# Altium schematic sheet Sheet1.SchDoc
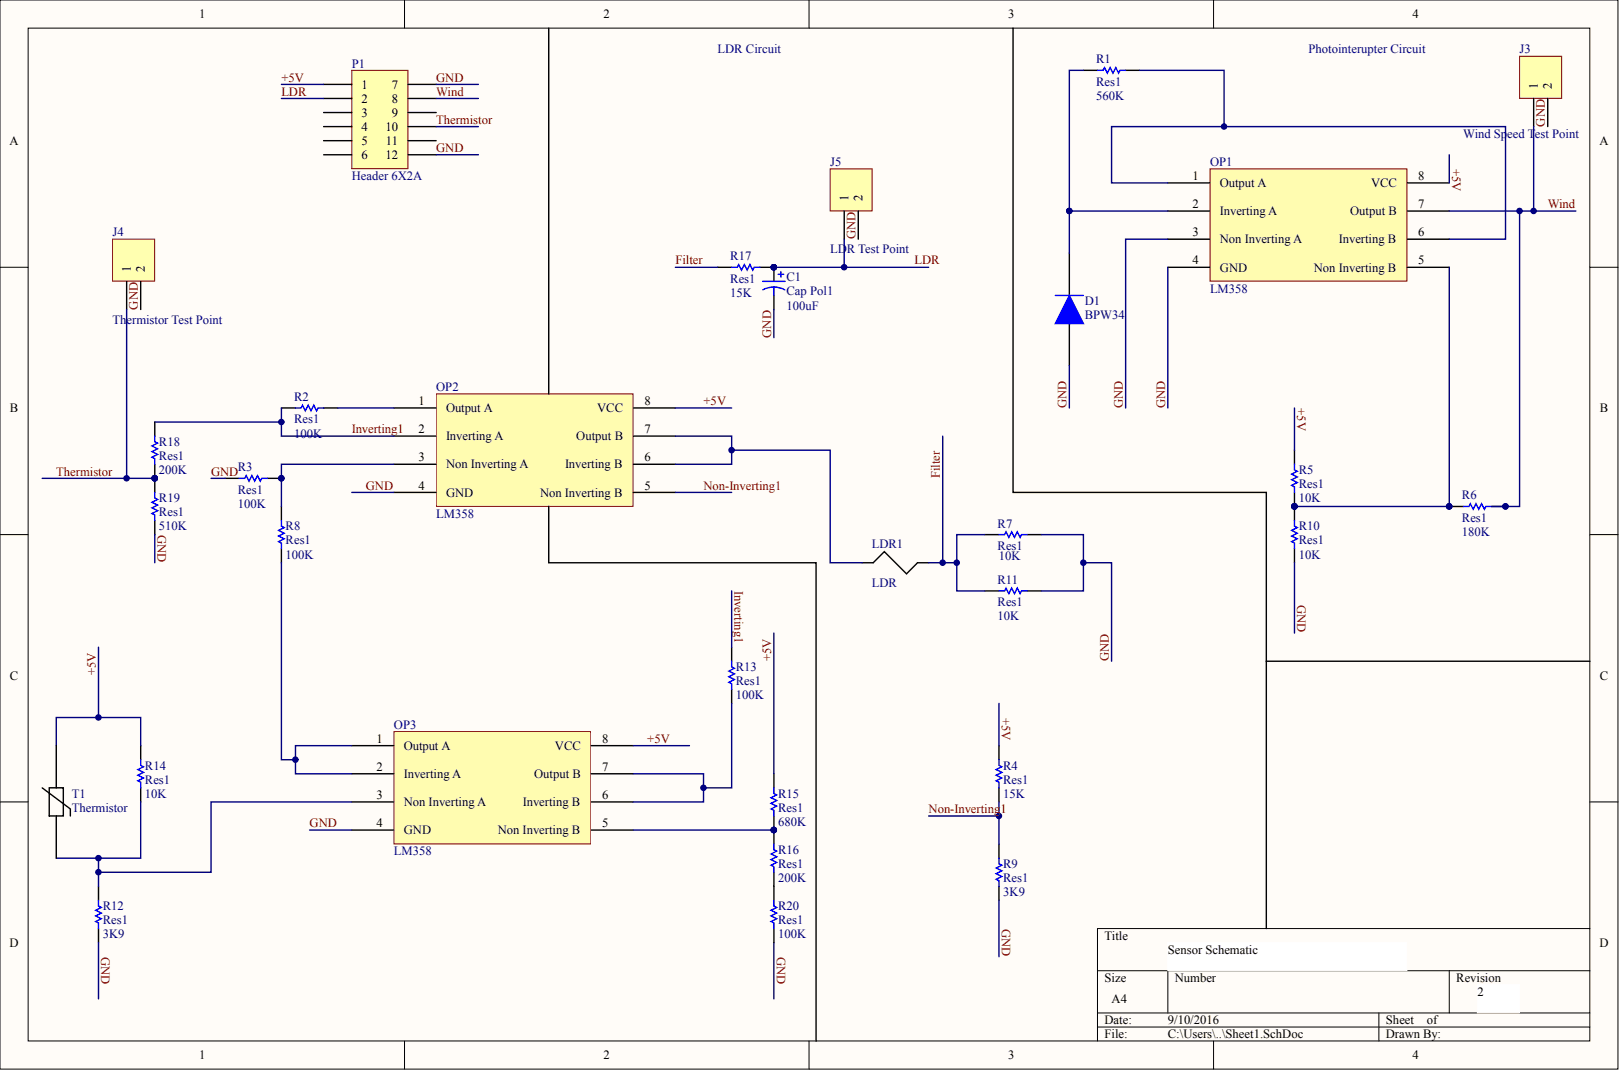
[diagram p0: Sheet1.SchDoc]

## Parts
C1  Cap Pol1  value 100uF
D1  BPW34
J3  Wind Speed Test Point
J4  Thermistor Test Point
J5  LDR Test Point
LDR1  LDR
OP1  LM358
OP2  LM358
OP3  LM358
P1  Header 6X2A
R1  Res1  value 560K
R2  Res1  value 100K
R3  Res1  value 100K
R4  Res1  value 15K
R5  Res1  value 10K
R6  Res1  value 180K
R7  Res1  value 10K
R8  Res1  value 100K
R9  Res1  value 3K9
R10  Res1  value 10K
R11  Res1  value 10K
R12  Res1  value 3K9
R13  Res1  value 100K
R14  Res1  value 10K
R15  Res1  value 680K
R16  Res1  value 200K
R17  Res1  value 15K
R18  Res1  value 200K
R19  Res1  value 510K
R20  Res1  value 100K
T1  Thermistor

## Nets
+5V: OP1.8(VCC) OP2.8(VCC) OP3.8(VCC) P1.1 R4.2 R5.2 R14.2 R15.2 T1.2
FILTER: LDR1.2 R7.1 R11.1 R17.1
GND: C1.2 D1.2 J3.2 J4.2 J5.2 OP1.3(Non_Inverting_A) OP1.4(GND) OP2.4(GND) OP3.4(GND) P1.7 P1.12 R3.1 R7.2 R9.1 R10.1 R11.2 R12.1 R19.1 R20.1
INVERTING1: OP2.2(Inverting_A) R2.1 R13.1 R18.2
LDR: C1.1 J5.1 P1.2 R17.2
NON-INVERTING1: OP2.5(Non_Inverting_B) R4.1 R9.2
THERMISTOR: J4.1 P1.10 R18.1 R19.2
WIND: J3.1 OP1.7(Output_B) P1.8 R6.1
NetD1_1: D1.1 OP1.2(Inverting_A) R1.1
NetLDR1_1: LDR1.1 OP2.6(Inverting_B) OP2.7(Output_B)
NetOP1_1: OP1.1(Output_A) OP1.6(Inverting_B) R1.2
NetOP1_5: OP1.5(Non_Inverting_B) R5.1 R6.2 R10.2
NetOP2_1: OP2.1(Output_A) R2.2
NetOP2_3: OP2.3(Non_Inverting_A) R3.2 R8.2
NetOP3_1: OP3.1(Output_A) OP3.2(Inverting_A) R8.1
NetOP3_3: OP3.3(Non_Inverting_A) R12.2 R14.1 T1.1
NetOP3_5: OP3.5(Non_Inverting_B) R15.1 R16.2
NetOP3_6: OP3.6(Inverting_B) OP3.7(Output_B) R13.2
NetR16_1: R16.1 R20.2

Not connected: P1 3 4 5 6 9 11

## Library data of the parts
R3 = (no symbol name): Value: 100K; Published: 8-Jun-2000; LatestRevisionDate: 17-Jul-2002; LatestRevisionNote: Re-released for DXP Platform.; PackageReference: AXIAL-0.3; PackageDescription: Axial Device, Thru-Hole; 2 Leads; 0.3 in Pin Spacing; Publisher: Altium Limited
R17 = (no symbol name): Value: 15K; Published: 8-Jun-2000; LatestRevisionDate: 17-Jul-2002; LatestRevisionNote: Re-released for DXP Platform.; PackageReference: AXIAL-0.3; PackageDescription: Axial Device, Thru-Hole; 2 Leads; 0.3 in Pin Spacing; Publisher: Altium Limited
C1 D1 J3-J5 LDR1 OP1-OP3 P1 R1 R2 R4-R16 R18-R20 T1 = (no symbol name)
  C1: Published: 8-Jun-2000; LatestRevisionDate: 17-Jul-2002; LatestRevisionNote: Re-released for DXP Platform.; PackageReference: RB7.6-15; PackageDescription: Polarized Capacitor (Radial); 2 Leads
  J3-J5 P1: LatestRevisionDate: 17-Jul-2002; LatestRevisionNote: Re-released for DXP Platform.
  R1 R2 R4-R16 R18-R20: Published: 8-Jun-2000; LatestRevisionDate: 17-Jul-2002; LatestRevisionNote: Re-released for DXP Platform.; PackageReference: AXIAL-0.3; PackageDescription: Axial Device, Thru-Hole; 2 Leads; 0.3 in Pin Spacing
  C1 J3-J5 P1 R1 R2 R4-R16 R18-R20: Publisher: Altium Limited
